# Altium schematic sheet L298N_Motor.SchDoc
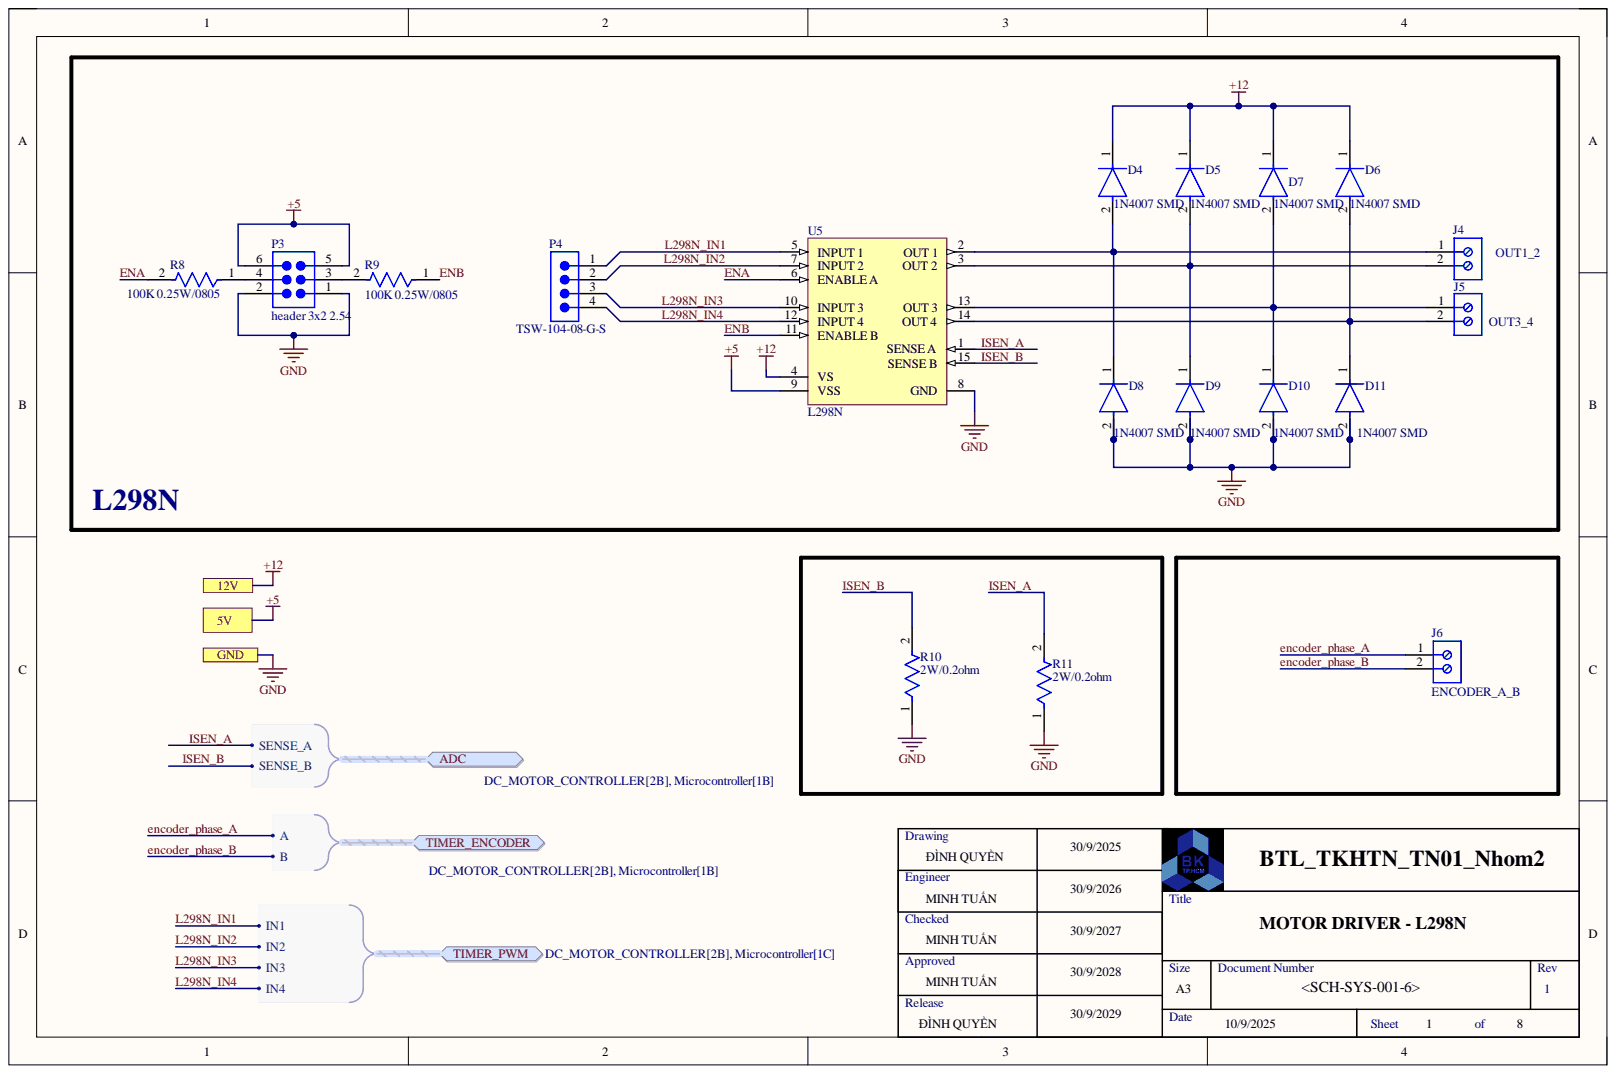
[diagram p0: L298N_Motor.SchDoc]

## Parts
D4  1N4007 SMD  [FP-1N4007_SMD-IPC_C]
D5  1N4007 SMD  [FP-1N4007_SMD-IPC_C]
D6  1N4007 SMD  [FP-1N4007_SMD-IPC_C]
D7  1N4007 SMD  [FP-1N4007_SMD-IPC_C]
D8  1N4007 SMD  [FP-1N4007_SMD-IPC_C]
D9  1N4007 SMD  [FP-1N4007_SMD-IPC_C]
D10  1N4007 SMD  [FP-1N4007_SMD-IPC_C]
D11  1N4007 SMD  [FP-1N4007_SMD-IPC_C]
J4  OUT1_2  [691254710002]
J5  OUT3_4  [691254710002]
J6  ENCODER_A_B  [691254710002]
P3  header 3x2 2.54  [SMTC-TSW-103-08-X-D]
P4  TSW-104-08-G-S  [SMTC-TSW-104-08-X-S]
R8  100K 0.25W/0805  [FP-AF0805-IPC_C]
R9  100K 0.25W/0805  [FP-AF0805-IPC_C]
R10  2W/0.2ohm  [FP-ERG3S-MFG]
R11  2W/0.2ohm  [FP-ERG3S-MFG]
U5  L298N  [STM-FM-15]

## Ports
5V, 12V, ADC, ADC.SENSE_A, ADC.SENSE_B, GND, TIMER_ENCODER, TIMER_ENCODER.A, TIMER_ENCODER.B, TIMER_PWM, TIMER_PWM.IN1, TIMER_PWM.IN2, TIMER_PWM.IN3, TIMER_PWM.IN4

## Nets
+5: P3.5 P3.6 U5.9(VSS) ; port 5V
+12: D4.1 D5.1 D6.1 D7.1 U5.4(VS) ; port 12V
ENA: R8.2 U5.6(ENABLE_A)
ENB: R9.1 U5.11(ENABLE_B)
encoder_phase_A: J6.1 ; port TIMER_ENCODER
encoder_phase_B: J6.2 ; port TIMER_ENCODER
GND: D8.2 D9.2 D10.2 D11.2 P3.1 P3.2 R10.1 R11.1 U5.8(GND) ; port GND
ISEN_A: R11.2 U5.1(SENSE_A) ; port ADC
ISEN_B: R10.2 U5.15(SENSE_B) ; port ADC
L298N_IN1: P4.1 U5.5(INPUT_1) ; port TIMER_PWM
L298N_IN2: P4.2 U5.7(INPUT_2) ; port TIMER_PWM
L298N_IN3: P4.3 U5.10(INPUT_3) ; port TIMER_PWM
L298N_IN4: P4.4 U5.12(INPUT_4) ; port TIMER_PWM
NetD4_2: D4.2 D8.1 J4.1 U5.2(OUT_1)
NetD5_2: D5.2 D9.1 J4.2 U5.3(OUT_2)
NetD6_2: D6.2 D11.1 J5.2 U5.14(OUT_4)
NetD7_2: D7.2 D10.1 J5.1 U5.13(OUT_3)
NetP3_3: P3.3 R9.2
NetP3_4: P3.4 R8.1

## Library data of the parts
D4-D11 = CMP-12389-000001-1: Rectifier, 1Kv, 1A, Do-214Ac Rohs Compliant: Yes; Component Kind: Standard; Library Name: Altium Content Vault
J4-J6 = CMP-1502-02591-2: NexarPartId: 105950848; Component Kind: Standard; Library Name: Altium Content Vault
P3 = CMP-1024-00337-1: 0.025" SQ Post Header, Through-hole, Vertical, -55 to 125 degC, 2.54 mm Pitch, 6-Pin, Male, RoHS; Manufacturer: Samtec; PartNumber: TSW-103-08-F-D; Pitch: 2.54 mm; Component Kind: Standard; ComponentLink1Description: Manufacturer URL; ComponentLink1URL: http://www.samtec.com; ComponentLink2Description: Datasheet; ComponentLink2URL: http://www.samtec.com/documents/webfiles/pdf/TSW_TH.PDF; ComponentLink3Description: Package Specification; ComponentLink3URL: http://www.samtec.com/documents/webfiles/cpdf/TSW-XXX-XX-XXX-X-XX-XXX-MKT.pdf; DatasheetVersion: F-214; Library Name: Altium Content Vault; Mounting Technology: Through-hole; Orientation: Vertical; PackageDescription: .025[0.64] SQ. Post Terminal Strip Assembly; PackageReference: TSW-103-08-X-D; PackageVersion: DM, 8/1988; RoHS: True; Temperature Range: -55 to 125 degC
P4 = CMP-1024-00357-1: 0.025" SQ Post Header, Through-hole, Vertical, -55 to 125 degC, 2.54 mm Pitch, 4-Pin, Male, RoHS; Manufacturer: Samtec; Pitch: 2.54 mm; Component Kind: Standard; ComponentLink1Description: Manufacturer URL; ComponentLink1URL: http://www.samtec.com; ComponentLink2Description: Datasheet; ComponentLink2URL: http://www.samtec.com/documents/webfiles/pdf/TSW_TH.PDF; ComponentLink3Description: Package Specification; ComponentLink3URL: http://www.samtec.com/documents/webfiles/cpdf/TSW-XXX-XX-XXX-X-XX-XXX-MKT.pdf; DatasheetVersion: F-214; Library Name: Altium Content Vault; Mounting Technology: Through-hole; Orientation: Vertical; PackageDescription: .025[0.64] SQ. Post Terminal Strip Assembly; PackageReference: TSW-104-08-X-S; PackageVersion: DM, 8/1988; PartNumber: TSW-104-08-G-S; RoHS: True; Temperature Range: -55 to 125 degC
R8 R9 = CMP-03412-045285-1: Anti-Sulfurated Chip Resistor Automotive Grade 75Ω 1% 0.125W ±100ppm/°C 0805; Max Power Dissipation: 125mW; Resistance: 75R; Case/Package: 0805; Component Kind: Standard; Features: Moisture Resistant; Height: 0.024inch; Length: 0.079inch; Library Name: Altium Content Vault; Max Operating Temperature: 155°C; Min Operating Temperature: -55°C; Number of Terminations: 2; Packaging: Tape and Reel; Resistor Type: Thick Film; RoHS Compliant: Yes; Series: AF; Temperature Coefficient: 100ppm/°C; Tolerance: 1%; Width: 0.049inch
R10 R11 = CMP-00287-55648916-1: ERG-3SJ361A Res Metal Oxide Film 360 Ohm 5% 3W ±300ppm/C Conformal Coated AXL; Lead Diameter: 800µm; Resistance: 360Ω; Temperature Coefficient: 300ppm/°C; Voltage Rating: 700V; Voltage Rating (DC): 350V; Case/Package: Axial; Component Kind: Standard; Composition: Metal Oxide; Contact Plating: Tin; Diameter: 5.5mm; Length: 15mm; Library Name: Altium Content Vault; Max Operating Temperature: 200°C; Max Power Dissipation: 3W; Min Operating Temperature: -55°C; Mount: Through Hole; NexarPartId: 55648916; Number of Terminations: 2; Power Rating: 3W; Radiation Hardening: No; RoHS: Compliant; Schedule B: 8533210090, 8533210090; Termination: Axial; Tolerance: 5%; Weight: 1.470008g
U5 = CMP-2000-06526-1: Dual Full-Bridge Driver, 4.8 to 46 V, -40 to 150 degC, 15-Pin FM (MW 15L), RoHS, Tube; Manufacturer: STMicroelectronics; PartNumber: L298; Driver Case Style: Multiwatt; Motor Type: FullBridge; No. of Outputs: 4Outputs; No. of Pins: 15Pins; Output Current: 2A; Output Voltage: 46V; Supply Voltage Max: 7V; Supply Voltage Min: 4.5V; SVHC: NoSVHC(17-Dec-2015); Automotive Qualification Standard: -; Component Kind: Standard; Datasheet URL: https://datasheet.ciiva.com/15109/getdatasheetpartid-50682-15109332.pdf; Datasheet Version: 01/2000; Library Name: Altium Content Vault; Manufacturer URL: http://www.st.com/; Mounting Technology: ThroughHole; MSL: -; Operating Temperature Max: 130°C; Operating Temperature Min: -25°C; Package Description: 15-Pin Flange Mount, Body 5 x 19.6 mm, Pitch 1.27 mm; Package Reference: FM-15; Packaging: Each; Product Range: -
